# Altium schematic sheet ESP32_SC_DevDesign.SchDoc
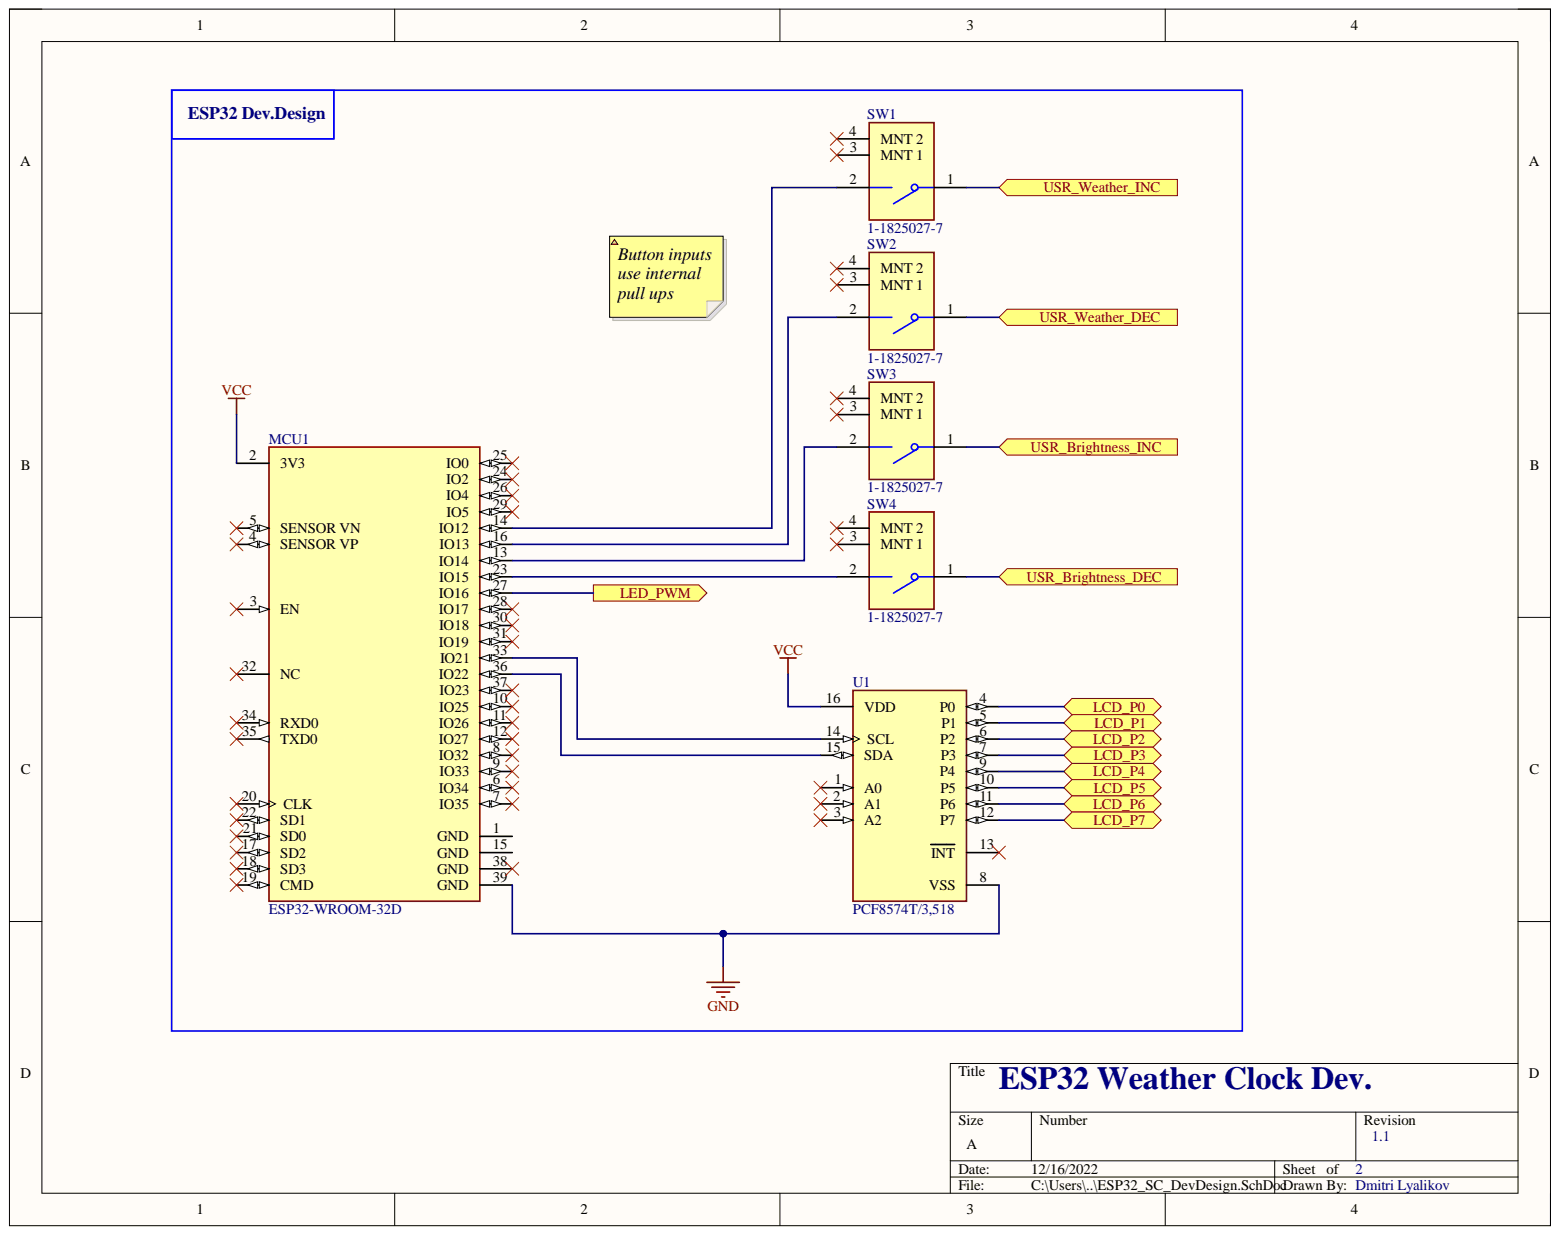
[diagram p0: ESP32_SC_DevDesign.SchDoc]

## Parts
MCU1  ESP32-WROOM-32D  [FP-ESP32-WROOM-32D-MFG]
MCU1  ESP32-WROOM-32D  [FP-ESP32-WROOM-32D-MFG]
SW1  1-1825027-7  [FP-1-1825027-7-MFG]
SW1  1-1825027-7  [FP-1-1825027-7-MFG]
SW2  1-1825027-7  [FP-1-1825027-7-MFG]
SW2  1-1825027-7  [FP-1-1825027-7-MFG]
SW3  1-1825027-7  [FP-1-1825027-7-MFG]
SW3  1-1825027-7  [FP-1-1825027-7-MFG]
SW4  1-1825027-7  [FP-1-1825027-7-MFG]
SW4  1-1825027-7  [FP-1-1825027-7-MFG]
U1  PCF8574T/3,518  [FP-SOT162-1-MFG]
U1  PCF8574T/3,518  [FP-SOT162-1-MFG]

## Ports
LCD_P0, LCD_P1, LCD_P2, LCD_P3, LCD_P4, LCD_P5, LCD_P6, LCD_P7, LED_PWM, USR_Brightness_DEC, USR_Brightness_INC, USR_Weather_DEC, USR_Weather_INC

## Nets
GND: MCU1.39 U1.8
VCC: MCU1.2 U1.16
LCD_P0: U1.4 ; port LCD_P0
LCD_P1: U1.5 ; port LCD_P1
LCD_P2: U1.6 ; port LCD_P2
LCD_P3: U1.7 ; port LCD_P3
LCD_P4: U1.9 ; port LCD_P4
LCD_P5: U1.10 ; port LCD_P5
LCD_P6: U1.11 ; port LCD_P6
LCD_P7: U1.12 ; port LCD_P7
LED_PWM: MCU1.27 ; port LED_PWM
USR_Brightness_DEC: SW4.1 ; port USR_Brightness_DEC
USR_Brightness_INC: SW3.1 ; port USR_Brightness_INC
USR_Weather_DEC: SW2.1 ; port USR_Weather_DEC
USR_Weather_INC: SW1.1 ; port USR_Weather_INC
NetMCU1_13: MCU1.13 SW3.2
NetMCU1_14: MCU1.14 SW1.2
NetMCU1_16: MCU1.16 SW2.2
NetMCU1_23: MCU1.23 SW4.2
NetMCU1_33: MCU1.33 U1.14
NetMCU1_36: MCU1.36 U1.15

Not connected: MCU1 1 3 4 5 6 7 8 9 10 11 12 15 17 18 19 20 21 22 24 25 26 28 29 30 31 32 34 35 37 38; SW1 3 4; SW2 3 4; SW3 3 4; SW4 3 4; U1 1 2 3 13

## Library data of the parts
MCU1 MCU1 = CMP-194065-000003-1: WIFI MODULE 32MBITS SPI FLASH; Manufacturer: Espressif Systems; Bandwidth: 72MHz; Data Rate: 150Mbits/s; Interface: I2C,SPI,UART,Ethernet,I2S,Other; Max Frequency: 2484MHz; Max Supply Voltage: 3.6V; Min Supply Voltage: 3V; Nominal Supply Current: 500mA; Number Of Adc Channels: 16; Number Of Gpio: 32; Sensitivity: -98dBm; Automotive: No; Category: UNK; Component Kind: Standard; ComponentLink2Description: Datasheet; ComponentLink2URL: https://www.espressif.com/sites/default/files/documentation/esp32-wroom-32d_esp32-wroom-32u_datasheet_en.pdf; Device Class L1: Integrated Circuits (ICs); Device Class L2: RF Semiconductors and Devices; Device Class L3: Transceivers; Digikey Description: WIFI MODULE 32MBITS SPI FLASH; Height: 3.2mm; Lead Free: Yes; Library Name: Altium Content Vault; Manufacturer Part Number: ESP32-WROOM-32D; Mouser Description: Wi-Fi/BT BLE Module ESP32-D0WD, SPI Flash, UART, P; Octopart: https://octopart.com/esp32-wroom-32d-espressif+systems-91040050; Package: ESP32-WROOM-32D; RoHS: TRUE; Temperature: -40°C to +85°C; Temperature Range High: +85°C; Temperature Range Low: -40°C
SW1 SW1 SW2 SW2 SW3 SW3 SW4 SW4 = CMP-03402-000018-1: SWITCH TACTILE SPST-NO 0.05A 24V; Actuator Color: White; Actuator Length: 4.24mm; Actuator Material: PBT; Actuator Type: Standard; Contact Current Rating: 50mA; Contact Resistance: 100mR; Electrical Life: 100000Cycles; Illumination Type: Non-Illuminated; Operating Force: 1.57N; Switch Function: Off-Mom; Switch Travel: 0.25mm; Throw Configuration: SPST; Component Kind: Standard; Contact Material: Copper Alloy; Contact Plating: Silver; Depth: 10.86mm; ELV: Compliant; Lead Free: Lead Free; Length: 7.11mm; Library Name: Altium Content Vault; Max Current Rating: 50mA; Max Operating Temperature: 85°C; Max Voltage Rating (DC): 24V; Mechanical Life: 100000Cycles; Min Operating Temperature: -35°C; Mount: Through Hole; Orientation: Right Angle; Package Quantity: 1000; Packaging: Loose Piece; Radiation Hardening: No; RoHS Compliant: Yes; Series: FSMRAJ, Alcoswitch; Termination: PC Pins; Type: Switch; Voltage Rating (DC): 24V
U1 U1 = CMP-10112-000010-1: I/O Expander 8 I²C 100kHz 16-SO; Manufacturer: NXP Semiconductors; Interface: I2C; Max Junction Temp: +125°C; Max Supply Voltage: 6V; Min Supply Voltage: 2.5V; Nominal Supply Current: 40uA; Number Of I/Os: 8; Standoff Height: 0.1mm; Ambient Temperature Range High: +85°C; Ambient Temperature Range Low: -40°C; Automotive: No; Category: IC; Component Kind: Standard; ComponentLink2Description: Datasheet; ComponentLink2URL: https://www.nxp.com/docs/en/data-sheet/PCF8574_PCF8574A.pdf; Device Class L1: Integrated Circuits (ICs); Device Class L2: Interface ICs; Device Class L3: I/O Expanders; Digikey Description: IC I/O EXPANDER I2C 8B 16SOIC; Footprint Url: https://www.nxp.com/docs/en/package-information/SOT162-1.pdf; Frequency: 100kHz; Height: 2.65mm; Ipc Land Pattern Name: SOIC127P1032X265-16; Lead Free: Yes; Library Name: Altium Content Vault; Manufacturer Part Number: PCF8574T/3,518; Mouser Description: PCF8574 Series 2.5 - 6V Remote 8-bit I/O Expander for I2C-bus - SOIC-16; Octopart: https://octopart.com/pcf8574t%2F3%2C518-nxp+semiconductors-10470852; Package: SOT162-1; RoHS: TRUE; Temperature: -40°C to +85°C; Temperature Range High: +85°C; Temperature Range Low: -40°C
